# Altium schematic sheet 二合一正反转.SchDoc
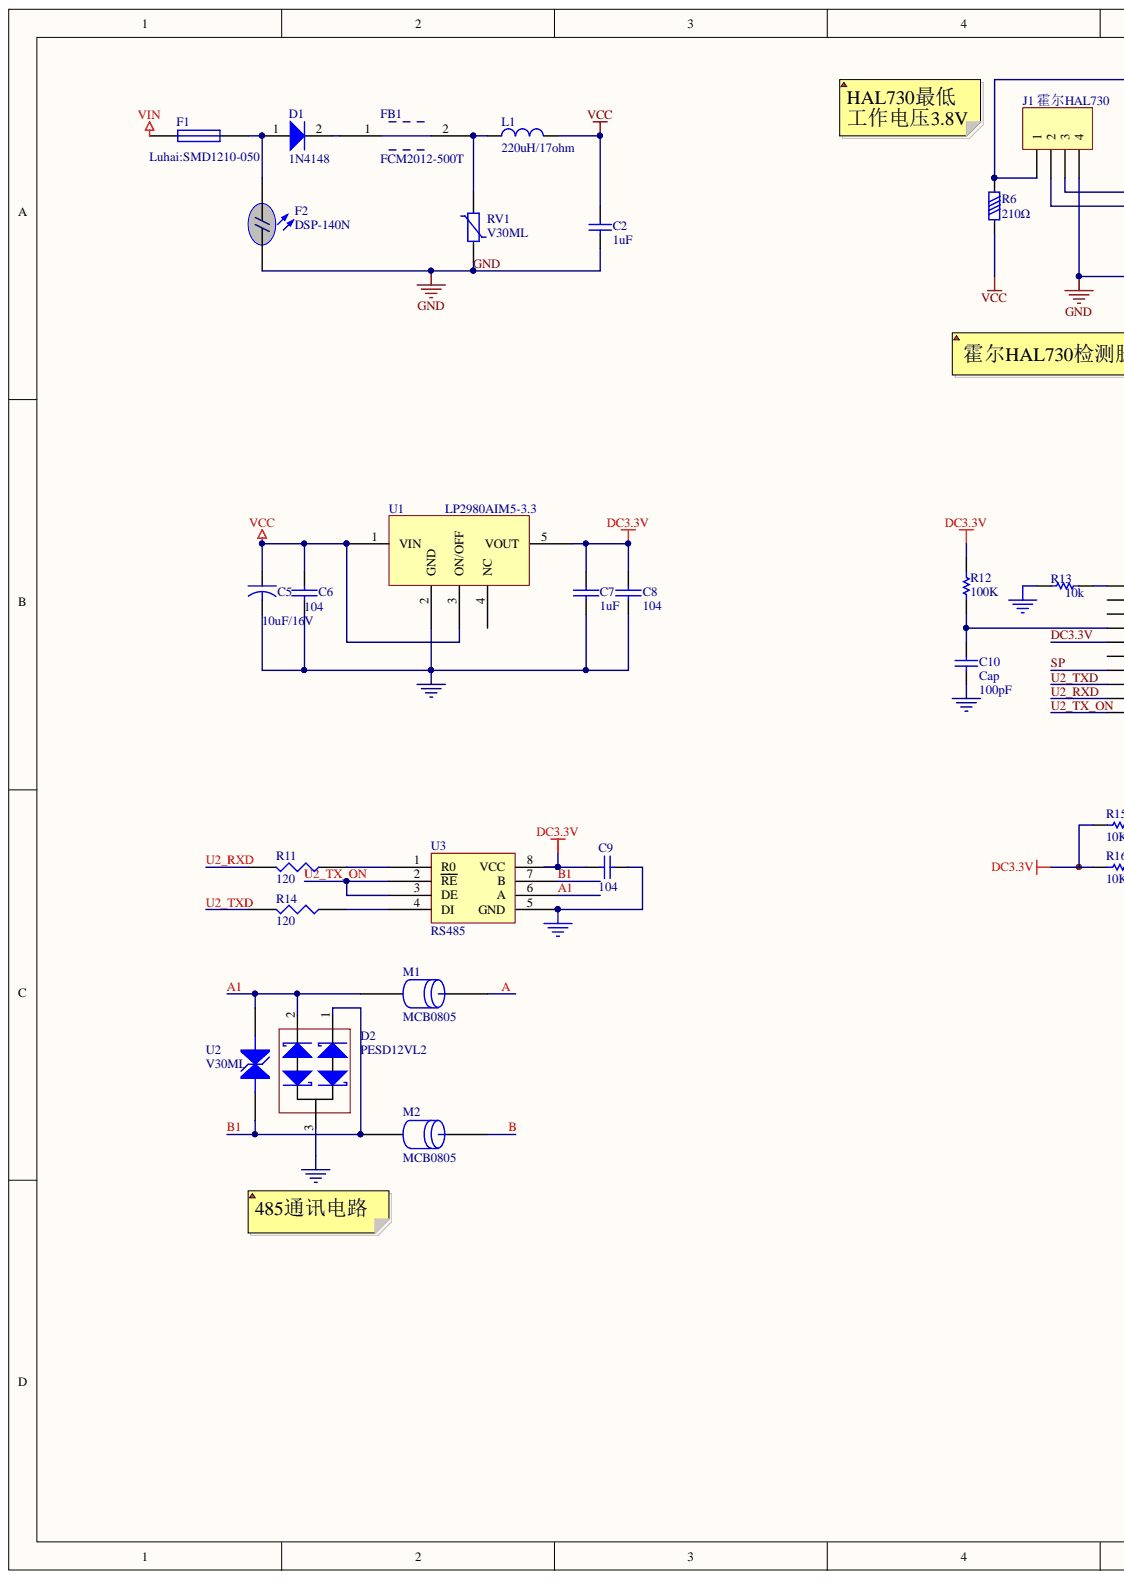
[diagram p0: 二合一正反转.SchDoc, left]
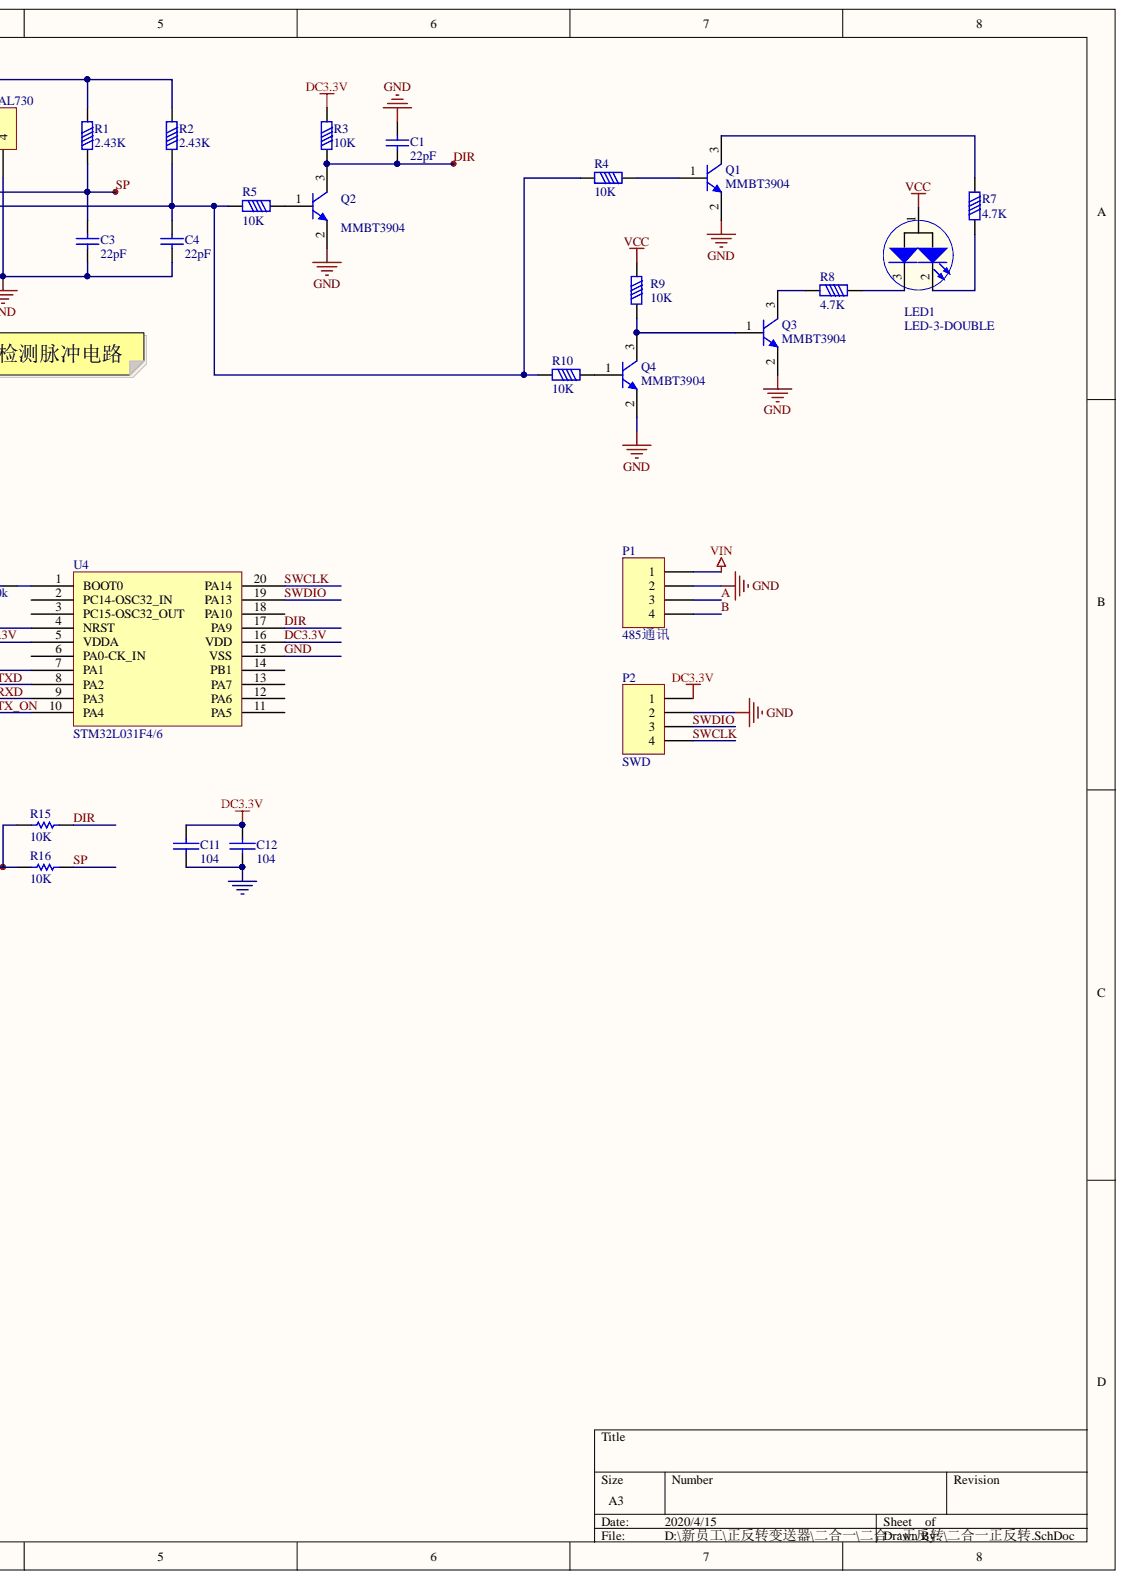
[diagram p1: 二合一正反转.SchDoc, right]

## Parts
C1  value 22pF  [0603C]  (hidden comment: Cap Chip 0603)
C2  1uF  [0805C]
C3  value 22pF  [0603C]  (hidden comment: Cap Chip 0603)
C4  value 22pF  [0603C]  (hidden comment: Cap Chip 0603)
C5  10uF/16V  [A3216]
C6  104  [0603C]
C7  1uF  [0603C]
C8  104  [0603C]
C9  104  [0603C]
C10  Cap  value 100pF  [0603C]
C11  104  [0603C]
C12  104  [0603C]
D1  1N4148  [1N4148]
D2  PESD12VL2  [SOT23]
F1  Luhai:SMD1210-050  [1210R]
F2  DSP-140N  [DSP]
FB1  FCM2012-500T  [FB]
J1  霍尔HAL730  [HDR1X4]
L1  220uH/17ohm  [220uH/17ohm]
LED1  LED-3-DOUBLE  [LED-R3-H5-DOUBLE]
M1  MCB0805  [0805F]
M2  MCB0805  [0805F]
P1  485通讯  [HDR1X4]
P2  SWD  [MHDR1X4]
Q1  MMBT3904  [MMBT3904]
Q2  MMBT3904  [MMBT3904]
Q3  MMBT3904  [MMBT3904]
Q4  MMBT3904  [MMBT3904]
R1  value 2.43K  [0603C]  (hidden comment: Resistor Chip 0603)
R2  value 2.43K  [0603C]  (hidden comment: Resistor Chip 0603)
R3  value 10K  [0603C]  (hidden comment: Resistor Chip 0603)
R4  value 10K  [0603C]  (hidden comment: Resistor Chip 0603)
R5  value 10K  [0603C]  (hidden comment: Resistor Chip 0603)
R6  value 210Ω  [0603C]  (hidden comment: Resistor Chip 0603)
R7  value 4.7K  [0603C]  (hidden comment: Resistor Chip 0603)
R8  value 4.7K  [0603C]  (hidden comment: Resistor Chip 0603)
R9  value 10K  [0603C]  (hidden comment: Resistor Chip 0603)
R10  value 10K  [0603C]  (hidden comment: Resistor Chip 0603)
R11  120  [0603R]
R12  100K  [0603R]
R13  10k  [0603R]
R14  120  [0603R]
R15  10K  [0603R]
R16  10K  [0603R]
RV1  V30ML  [RV]
U1  LP2980AIM5-3.3  [SOT-23-5]
U2  V30ML  [0805C-E]
U3  RS485  [SOP8]
U4  STM32L031F4/6  [TSSOP20]

## Nets
VCC: C2.2 C5.1 C6.1 L1.2 LED1.1 R6.2 R9.1 U1.1(VIN) U1.3(ON/OFF)
VIN: F1.1 P1.1
A: M1.2 P1.3
A1: D2.2 M1.1 U2.2 U3.6(A)
B: M2.2 P1.4
B1: D2.1 M2.1 U2.1 U3.7(B)
DC3.3V: C7.1 C8.1 C9.1 C11.1 C12.1 P2.1 R3.2 R12.1 R15.2 R16.1 U1.5(VOUT) U3.8(VCC) U4.5(VDDA) U4.16(VDD)
DIR: C1.1 Q2.3 R3.1 R15.1 U4.17(PA9)
GND: C1.2 C2.1 C3.1 C4.1 C5.2 C6.2 C7.2 C8.2 C9.2 C10.1 C11.2 C12.2 D2.3 F2.2 J1.4 P1.2 P2.2 Q1.2 Q2.2 Q3.2 Q4.2 R13.2 RV1.1 U1.2(GND) U3.5(GND) U4.15(VSS)
SP: C3.2 J1.3 R1.1 R16.2 U4.7(PA1)
SWCLK: P2.4 U4.20(PA14)
SWDIO: P2.3 U4.19(PA13)
U2_RXD: R11.2 U4.9(PA3)
U2_TX_ON: U3.2(RE) U3.3(DE) U4.10(PA4)
U2_TXD: R14.2 U4.8(PA2)
NetC4_2: C4.2 J1.2 R2.1 R4.2 R5.2 R10.2
NetC10_2: C10.2 R12.2 U4.4(NRST)
NetD1_1: D1.1 F1.2 F2.1
NetD1_2: D1.2 FB1.1
NetFB1_2: FB1.2 L1.1 RV1.2
NetJ1_1: J1.1 R1.2 R2.2 R6.1
NetLED1_2: LED1.2 R7.2
NetLED1_3: LED1.3 R8.1
NetQ1_1: Q1.1 R4.1
NetQ1_3: Q1.3 R7.1
NetQ2_1: Q2.1 R5.1
NetQ3_1: Q3.1 Q4.3 R9.2
NetQ3_3: Q3.3 R8.2
NetQ4_1: Q4.1 R10.1
NetR11_1: R11.1 U3.1(R0)
NetR13_1: R13.1 U4.1(BOOT0)
NetR14_1: R14.1 U3.4(DI)

Not connected: U1 4(NC); U4 2(PC14-OSC32_IN) 3(PC15-OSC32_OUT) 6(PA0-CK_IN) 11(PA5) 12(PA6) 13(PA7) 14(PB1) 18(PA10)

## Library data of the parts
C1 C3 C4 = Cap Chip 0603: Polarized Capacitor (Radial); Component Kind: Standard; Published: 8-Jun-2000; Revision: July-2002: Re-released for DXP Platform.; Library Name: EButterflyIntegratedLibrary.IntLib; Publisher: Altium Limited
C2 = Cap Chip 0805: Polarized Capacitor (Radial); Value: 104; Component Kind: Standard; Published: 8-Jun-2000; Revision: July-2002: Re-released for DXP Platform.; Library Name: EButterflyIntegratedLibrary.IntLib; Publisher: Altium Limited
C5 = CAPACITOR: Component Kind: Standard; Description: Capacitor
C6-C8 C11 C12 = CAP: Capacitor; Component Kind: Standard
C9 C10 = Cap: Capacitor; Component Kind: Standard
  C10: LatestRevisionDate: 17-Jul-2002; LatestRevisionNote: Re-released for DXP Platform.; Library Name: Miscellaneous Devices.IntLib; PackageReference: RAD-0.3; Published: 8-Jun-2000; Signal Integrity: Cap; Simulation: CAP; Publisher: Altium Limited
D2 = TVS: Component Kind: Standard; Library Name: lewis lib.SCHLIB
F1 = Fuse 1: Fuse; Component Kind: Standard; LatestRevisionDate: 17-Jul-2002; LatestRevisionNote: Re-released for DXP Platform.; Library Name: Miscellaneous Devices.IntLib; PackageDescription: Thru-Hole; 2 Leads; PackageReference: PIN-W2/E2.8; Published: 8-Jun-2000; Simulation: FUSE; Publisher: Altium Limited
J1 P2 = Header 4: Header, 4-Pin; Component Kind: Standard; LatestRevisionDate: 17-Jul-2002; LatestRevisionNote: Re-released for DXP Platform.; Signal Integrity: Connector; Publisher: Altium Limited
  P2: Library Name: Miscellaneous Connectors.IntLib
  J1: Library Name: EButterflyIntegratedLibrary.IntLib
LED1 = DOUB-LED: Component Kind: Standard; Library Name: Integrated_Library1.IntLib
M1 M2 = MAGNETIC BEAD: Component Kind: Standard; Description: magnetic bead; Library Name: lewis lib.SCHLIB
P1 = Header 4H: Header, 4-Pin, Right Angle; Component Kind: Standard; LatestRevisionDate: 17-Jul-2002; LatestRevisionNote: Re-released for DXP Platform.; Library Name: Miscellaneous Connectors.IntLib; Signal Integrity: Connector; Publisher: Altium Limited
R1-R10 = Resistor Chip 0603: Resistor; Component Kind: Standard; PCB3D: R0603; Published: 8-Jun-2000; Revision: July-2002: Re-released for DXP Platform.; Library Name: EButterflyIntegratedLibrary.IntLib; Publisher: Altium Limited
R11 R14 = RES1: Component Kind: Standard
R12 R13 R15 R16 = Res1: Resistor; Component Kind: Standard; LatestRevisionDate: 17-Jul-2002; LatestRevisionNote: Re-released for DXP Platform.; Library Name: Miscellaneous Devices.IntLib; Published: 8-Jun-2000; Signal Integrity: Res; Simulation: RESISTOR; Publisher: Altium Limited
D1 = 1N4148: Component Kind: Standard; Library Name: Integrated_Library1.IntLib
F2 = DSP-140N: Component Kind: Standard; Library Name: Integrated_Library1.IntLib
FB1 = FCM2012-500T: Component Kind: Standard; Library Name: Integrated_Library1.IntLib
L1 = 220uH/17ohm: Component Kind: Standard; Library Name: Integrated_Library1.IntLib
Q1-Q4 = MMBT3904: Component Kind: Standard; Library Name: Integrated_Library1.IntLib
RV1 U2 = V30ML: Component Kind: Standard
  RV1: Library Name: Integrated_Library1.IntLib
U1 = LP2980AIM5-3.3: Component Kind: Standard; Library Name: lewis lib.SCHLIB
U3 = RS485: Component Kind: Standard
U4 = STM32L031F4/6: Component Kind: Standard; Description: STM32L031F4/6; Library Name: lewis lib.SCHLIB
